# Altium schematic sheet UART.SchDoc(UART)
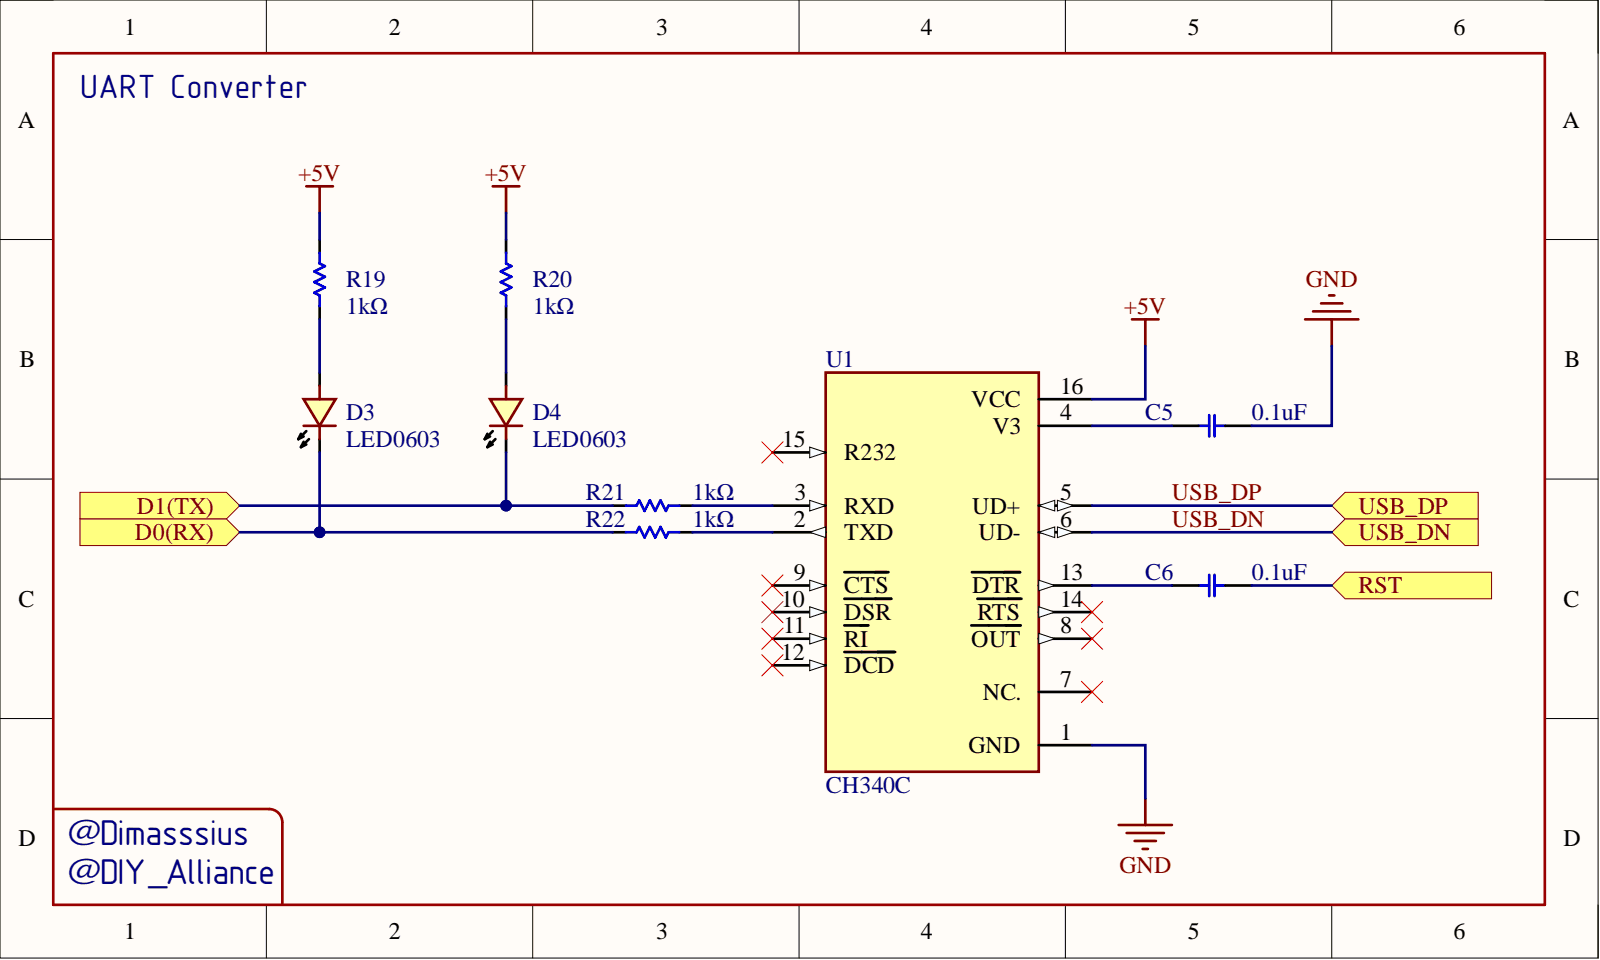
[diagram p0: UART.SchDoc]

## Parts
C5  0.1uF  [CAP_SMD_MLCC_C0603_(M1608)_C0402A_(M1005A)]
C6  0.1uF  [CAP_SMD_MLCC_C0603_(M1608)_C0402A_(M1005A)]
D3  LED0603  [LED 0603_(1608)_0402A_(1005)]
D4  LED0603  [LED 0603_(1608)_0402A_(1005)]
R19  1kΩ  [RES_SMD_R0603_(M1608)_R0402A_(M1005A)]
R20  1kΩ  [RES_SMD_R0603_(M1608)_R0402A_(M1005A)]
R21  1kΩ  [RES_SMD_R0603_(M1608)_R0402A_(M1005A)]
R22  1kΩ  [RES_SMD_R0603_(M1608)_R0402A_(M1005A)]
U1  CH340C  [SOIC127P600X180-16N]

## Ports
D0(RX), D1(TX), RST, USB_DN, USB_DP

## Nets
+5V: R19.1 R20.1 U1.16(VCC)
GND: C5.2 U1.1(GND)
D0(RX): D3.1 R22.1 ; port D0(RX)
D1(TX): D4.1 R21.1 ; port D1(TX)
RST: C6.2 ; port RST
USB_DN: U1.6(UD-) ; port USB_DN
USB_DP: U1.5(UD+) ; port USB_DP
NetC5_1: C5.1 U1.4(V3)
NetC6_1: C6.1 U1.13(DTR)
NetD3_2: D3.2 R19.2
NetD4_2: D4.2 R20.2
NetR21_2: R21.2 U1.3(RXD)
NetR22_2: R22.2 U1.2(TXD)

Not connected: U1 7(NC.) 8(OUT) 9(CTS) 10(DSR) 11(RI) 12(DCD) 14(RTS) 15(R232)

## Library data of the parts
C5 C6 = CAP_SMD_MLCC_0.1UF_6.3V: Capacitor, Ceramic (MLCC), SMD, 100nF, 6.3V, ±10%, X7R, Multilayer Ceramic Capacitors MLCC - SMD/SMT, ROHS; Manufacturer: Murata Electronics North America; Part Number: LLM315R71C104MA11L; @ qty: 0; Minimum Quantity: 1; Tolerance: ±20%; Unit Price (USD): 0.9; Voltage: 16V; Applications: Bypass, Decoupling; Component Kind: Standard; Datasheets: http://www.murata.com/~/media/webrenewal/support/library/catalog/products/capacitor/mlcc/c02e.pdf; Digi-Key Part Number: 490-4495-1-ND; Factory Stock: 0; Features: Low ESL (Multi-Terminal); Height - Seated (Max): -; Image: //media.digikey.com/Renders/Murata%20Renders/1206(LLM315).jpg; Lead Spacing: -; Lead Style: -; Library Name: Capacitors.SchLib; Mounting Type: Surface Mount, MLCC; Operating Temperature: -55°C ~ 125°C; Package / Case: -; Packaging: Cut Tape (CT); Part Status: Active; Quantity Available: 2915; Ratings: -; Series: LLM; Size / Dimension: -; Temperature Coefficient: X7R; Thickness (Max): -; Value: 0.1uF
D3 D4 = XL-1608SURC-06: LED Indication - Discrete, 0603, 20mA, 225mcd, Colorless Transparent Lens, -20℃~+85℃, Positive Stick, 620nm~630nm, Red, 120°, 50mW, 2.3V, LED Indication - Discrete, ROHS; Component Kind: Standard; Datasheet Link: https://katalog.we-online.de/led/datasheet/150060GS55040.pdf; Height: 0.5mm; Library Name: SamacSys.SchLib; Value: LED0603
R19-R22 = RES_SMD_1KΩ: Resistor, Thick Film Resistor, SMD, 1kΩ, ±5%, Chip Resistor - Surface Mount, ROHS; Component Kind: Standard; Library Name: Resistors.SchLib; Value: 1kΩ
U1 = CH340C: USB Converter, SOP-16, 2Mbps Transceiver, USB 2.0, USB Converters,  ROHS; Component Kind: Standard; Library Name: SamacSys.SchLib; Value: CH340C
